# Altium schematic sheet 01 POWER.SchDoc
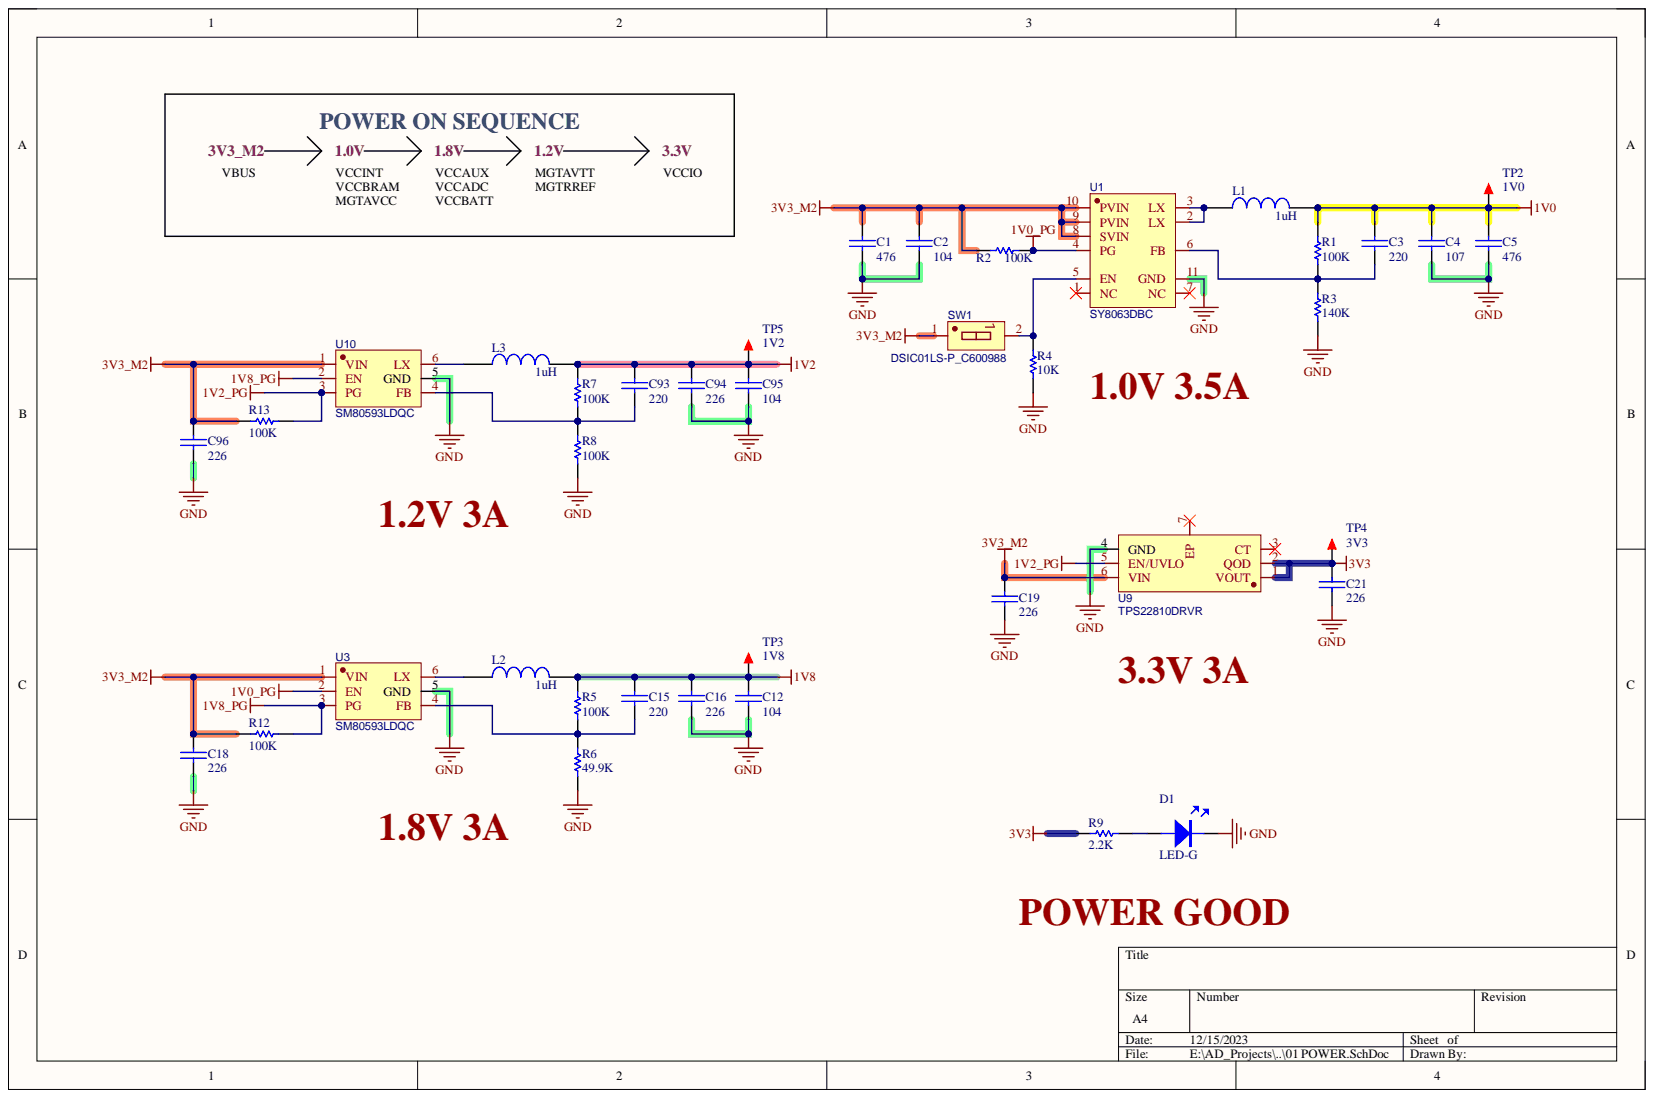
[diagram p0: 01 POWER.SchDoc]

## Parts
C1  476  [0603C]
C2  104  [0402C]
C3  220  [0402C]
C4  107  [1206C]
C5  476  [0603C]
C12  104  [0402C]
C15  220  [0402C]
C16  226  [0603C]
C18  226  [0603C]
C19  226  [0603C]
C21  226  [0603C]
C93  220  [0402C]
C94  226  [0603C]
C95  104  [0402C]
C96  226  [0603C]
D1  LED-G  [LED-0603G]
L1  1uH  [0420L]
L2  1uH  [252012L]
L3  1uH  [252012L]
R1  100K  [0402R]
R2  100K  [0402R]
R3  140K  [0402R]
R4  10K  [0402R]
R5  100K  [0402R]
R6  49.9K  [0402R]
R7  100K  [0402R]
R8  100K  [0402R]
R9  2.2K  [0402R]
R12  100K  [0402R]
R13  100K  [0402R]
SW1  DSIC01LS-P_C600988  [SW-SMD_2P-L3.5-W6.2-P2.54-LS9.8]
TP2  1V0  [TEST 0.8]
TP3  1V8  [TEST 0.8]
TP4  3V3  [TEST 0.8]
TP5  1V2  [TEST 0.8]
U1  SY8063DBC  [DFN-10_L3.0-W3.0-P0.50-BL-EP]
U3  SM80593LDQC  [DFN-6_L1.5-W1.5-P0.50-BL]
U9  TPS22810DRVR  [WSON-6_L2.0-W2.0-P0.65-TL-EP]
U10  SM80593LDQC  [DFN-6_L1.5-W1.5-P0.50-BL]

## Nets
1V0: C3.1 C4.2 C5.2 L1.2 R1.1 TP2.1
1V0_PG: R2.1 U1.4(PG) U3.2(EN)
1V2: C93.1 C94.1 C95.1 L3.2 R7.1 TP5.1
1V2_PG: R13.2 U9.5(EN/UVLO) U10.3(PG)
1V8: C12.1 C15.1 C16.1 L2.2 R5.1 TP3.1
1V8_PG: R12.2 U3.3(PG) U10.2(EN)
3V3: C21.1 R9.1 TP4.1 U9.1(VOUT) U9.2(QOD)
3V3_M2: C1.2 C2.2 C18.1 C19.1 C96.1 R2.2 R12.1 R13.1 SW1.1 U1.8(SVIN) U1.9(PVIN) U1.10(PVIN) U3.1(VIN) U9.6(VIN) U10.1(VIN)
GND: C1.1 C2.1 C4.1 C5.1 C12.2 C16.2 C18.2 C19.2 C21.2 C94.2 C95.2 C96.2 D1.K R3.2 R4.2 R6.2 R8.2 U1.11(GND) U3.5(GND) U9.4(GND) U10.5(GND)
NetC3_2: C3.2 R1.2 R3.1 U1.6(FB)
NetC15_2: C15.2 R5.2 R6.1 U3.4(FB)
NetC93_2: C93.2 R7.2 R8.1 U10.4(FB)
NetD1_A: D1.A R9.2
NetL1_1: L1.1 U1.2(LX) U1.3(LX)
NetL2_1: L2.1 U3.6(LX)
NetL3_1: L3.1 U10.6(LX)
NetR4_1: R4.1 SW1.2 U1.5(EN)

Not connected: U1 1(NC) 7(NC); U9 3(CT) 7(EP)

## Library data of the parts
C1-C5 C12 C15 C16 C18 C19 C21 C93-C96 = CAP: 无极性电容; Component Kind: Standard; Library Name: 电容.IntLib
D1 = LED: Component Kind: Standard; Library Name: LED.IntLib
L1-L3 = INDUCTOR: Component Kind: Standard; Description: INDUCTOR; Library Name: 电感.IntLib
R1-R9 R12 R13 = RES: Component Kind: Standard; Library Name: 电阻.IntLib
SW1 = 1P拨码开关SMD: Manufacturer: XKB Connectivity(中国星坤); Contributor: LCSC; Component Kind: Standard; JLCPCB Part Class: Extended Part; Library Name: 未分类IC.IntLib; Manufacturer Part: DSIC01LS-P; spicePre: S; spiceSymbolName: DSIC01LS-P_C600988; Supplier: LCSC; Supplier Part: C600988
TP2-TP5 = TEST: 测试点; 版权归属: 源创客; BOM名称: 测试点; Component Kind: Standard; 学习资源: www.ooocke.com; Library Name: 测试调试.IntLib
U1 = SY8063DBC: BOM_Manufacturer: silergy(矽力杰); Contributor: LCSC; BOM_JLCPCB Part Class: Extended Part; BOM_Manufacturer Part: SY8063DBC; BOM_Supplier: LCSC; BOM_Supplier Part: C178250; Component Kind: Standard; Library Name: 未分类IC.IntLib; spicePre: U; spiceSymbolName: SY8063DBC
U3 U10 = SM80593LDQC: BOM_Manufacturer: silergy(矽力杰); Contributor: lcsc; BOM_Manufacturer Part: SM80593LDQC; BOM_Supplier: LCSC; BOM_Supplier Part: C3151903; Component Kind: Standard; Library Name: 未分类IC.IntLib; link: https://atta.szlcsc.com/upload/public/pdf/source/20220628/A6CA9B87DD4EAA9C1464F36D4D99E4DA.pdf; spicePre: U; spiceSymbolName: SM80593LDQC
U9 = TPS22810DRVR: BOM_Manufacturer: TI(德州仪器); Contributor: LCSC; BOM_JLCPCB Part Class: Extended Part; BOM_Manufacturer Part: TPS22810DRVR; BOM_Supplier: LCSC; BOM_Supplier Part: C527679; Component Kind: Standard; Library Name: 未分类IC.IntLib; spicePre: U; spiceSymbolName: TPS22810DRVR
